# Altium schematic sheet Sheet1.SchDoc(Sheet1)
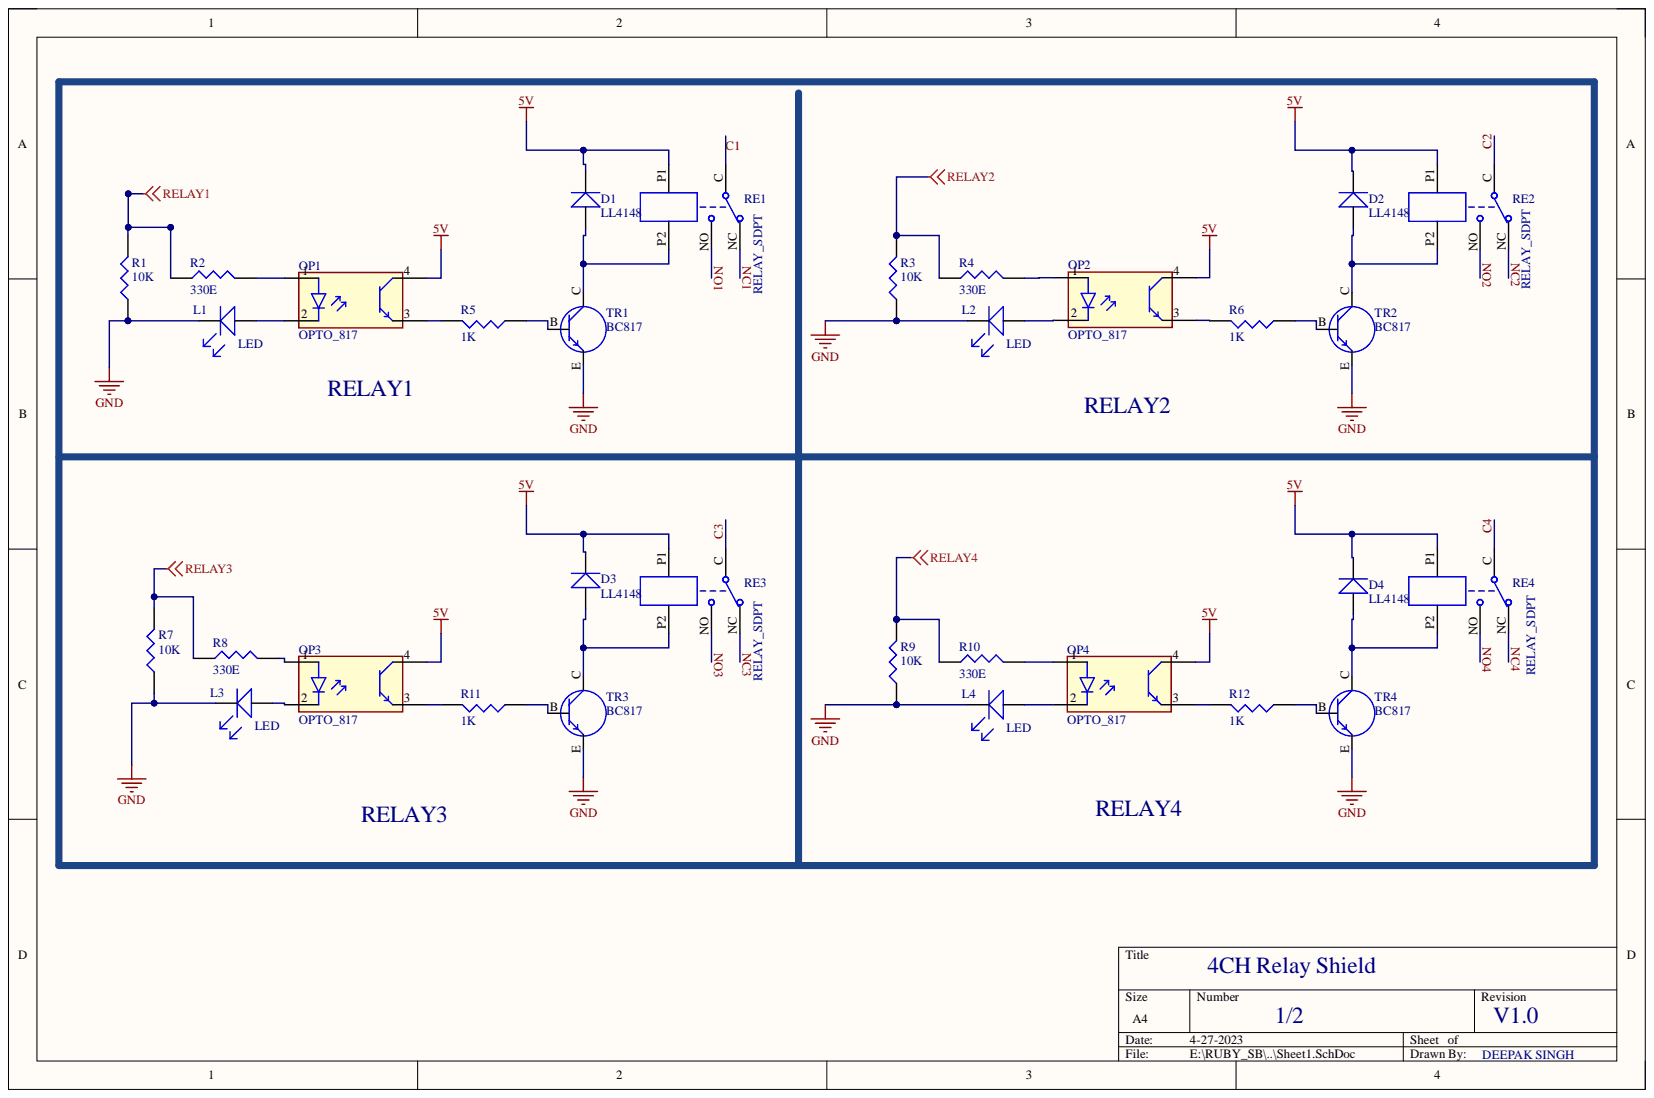
[diagram p0: Sheet1.SchDoc]

## Parts
D1  LL4148  [LL4148_SOD80]
D2  LL4148  [MINI_MELF_SOD80]
D3  LL4148  [MINI_MELF_SOD80]
D4  LL4148  [MINI_MELF_SOD80]
L1  LED  [LED_0603]
L2  LED  [LED_0603]
L3  LED  [LED_0603]
L4  LED  [LED_0603]
OP1  OPTO_817  [EL357N_OPTO]
OP2  OPTO_817  [EL357N_OPTO]
OP3  OPTO_817  [EL357N_OPTO]
OP4  OPTO_817  [EL357N_OPTO]
R1  10K  [R_0603]
R2  330E  [R_0603]
R3  10K  [R_0603]
R4  330E  [R_0603]
R5  1K  [R_0603]
R6  1K  [R_0603]
R7  10K  [R_0603]
R8  330E  [R_0603]
R9  10K  [R_0603]
R10  330E  [R_0603]
R11  1K  [R_0603]
R12  1K  [R_0603]
RE1  RELAY_SDPT  [HLS8L-DC5V RELAY_5V]
RE2  RELAY_SDPT  [HLS8L-DC5V RELAY_5V]
RE3  RELAY_SDPT  [HLS8L-DC5V RELAY_5V]
RE4  RELAY_SDPT  [HLS8L-DC5V RELAY_5V]
TR1  BC817  [SOT23]
TR2  BC817  [SOT23]
TR3  BC817  [SOT23]
TR4  BC817  [SOT23]

## Nets
5V: D1.K D2.K D3.K D4.K OP1.4 OP2.4 OP3.4 OP4.4 RE1.P1 RE2.P1 RE3.P1 RE4.P1
GND: L1.K L2.K L3.K L4.K R1.1 R3.1 R7.1 R9.1 TR1.E TR2.E TR3.E TR4.E
RELAY1: R1.2 R2.1
RELAY2: R3.2 R4.1
RELAY3: R7.2 R8.1
RELAY4: R9.2 R10.1
C1: RE1.C
C2: RE2.C
C3: RE3.C
C4: RE4.C
NC1: RE1.NC
NC2: RE2.NC
NC3: RE3.NC
NC4: RE4.NC
NO1: RE1.NO
NO2: RE2.NO
NO3: RE3.NO
NO4: RE4.NO
NetD1_A: D1.A RE1.P2 TR1.C
NetD2_A: D2.A RE2.P2 TR2.C
NetD3_A: D3.A RE3.P2 TR3.C
NetD4_A: D4.A RE4.P2 TR4.C
NetL1_A: L1.A OP1.2
NetL2_A: L2.A OP2.2
NetL3_A: L3.A OP3.2
NetL4_A: L4.A OP4.2
NetOP1_1: OP1.1 R2.2
NetOP1_3: OP1.3 R5.2
NetOP2_1: OP2.1 R4.2
NetOP2_3: OP2.3 R6.2
NetOP3_1: OP3.1 R8.2
NetOP3_3: OP3.3 R11.2
NetOP4_1: OP4.1 R10.2
NetOP4_3: OP4.3 R12.2
NetR5_1: R5.1 TR1.B
NetR6_1: R6.1 TR2.B
NetR11_1: R11.1 TR3.B
NetR12_1: R12.1 TR4.B

## Library data of the parts
D1-D4 = DIODE: Component Kind: Standard; Library Name: dxp_pasl_lib.IntLib
R1-R12 = RES: Component Kind: Standard; Library Name: dxp_pasl_lib.IntLib
TR1-TR4 = TRANSISTER_NPN: Component Kind: Standard; Library Name: dxp_pasl_lib.IntLib
L1-L4 = LED: Component Kind: Standard; Library Name: dxp_pasl_lib.IntLib
OP1-OP4 = OPTO_817: Component Kind: Standard; Library Name: dxp_pasl_lib.IntLib
RE1-RE4 = RELAY_SDPT: Component Kind: Standard; Library Name: dxp_pasl_lib.IntLib
